# Altium schematic sheet config.SchDoc(config)
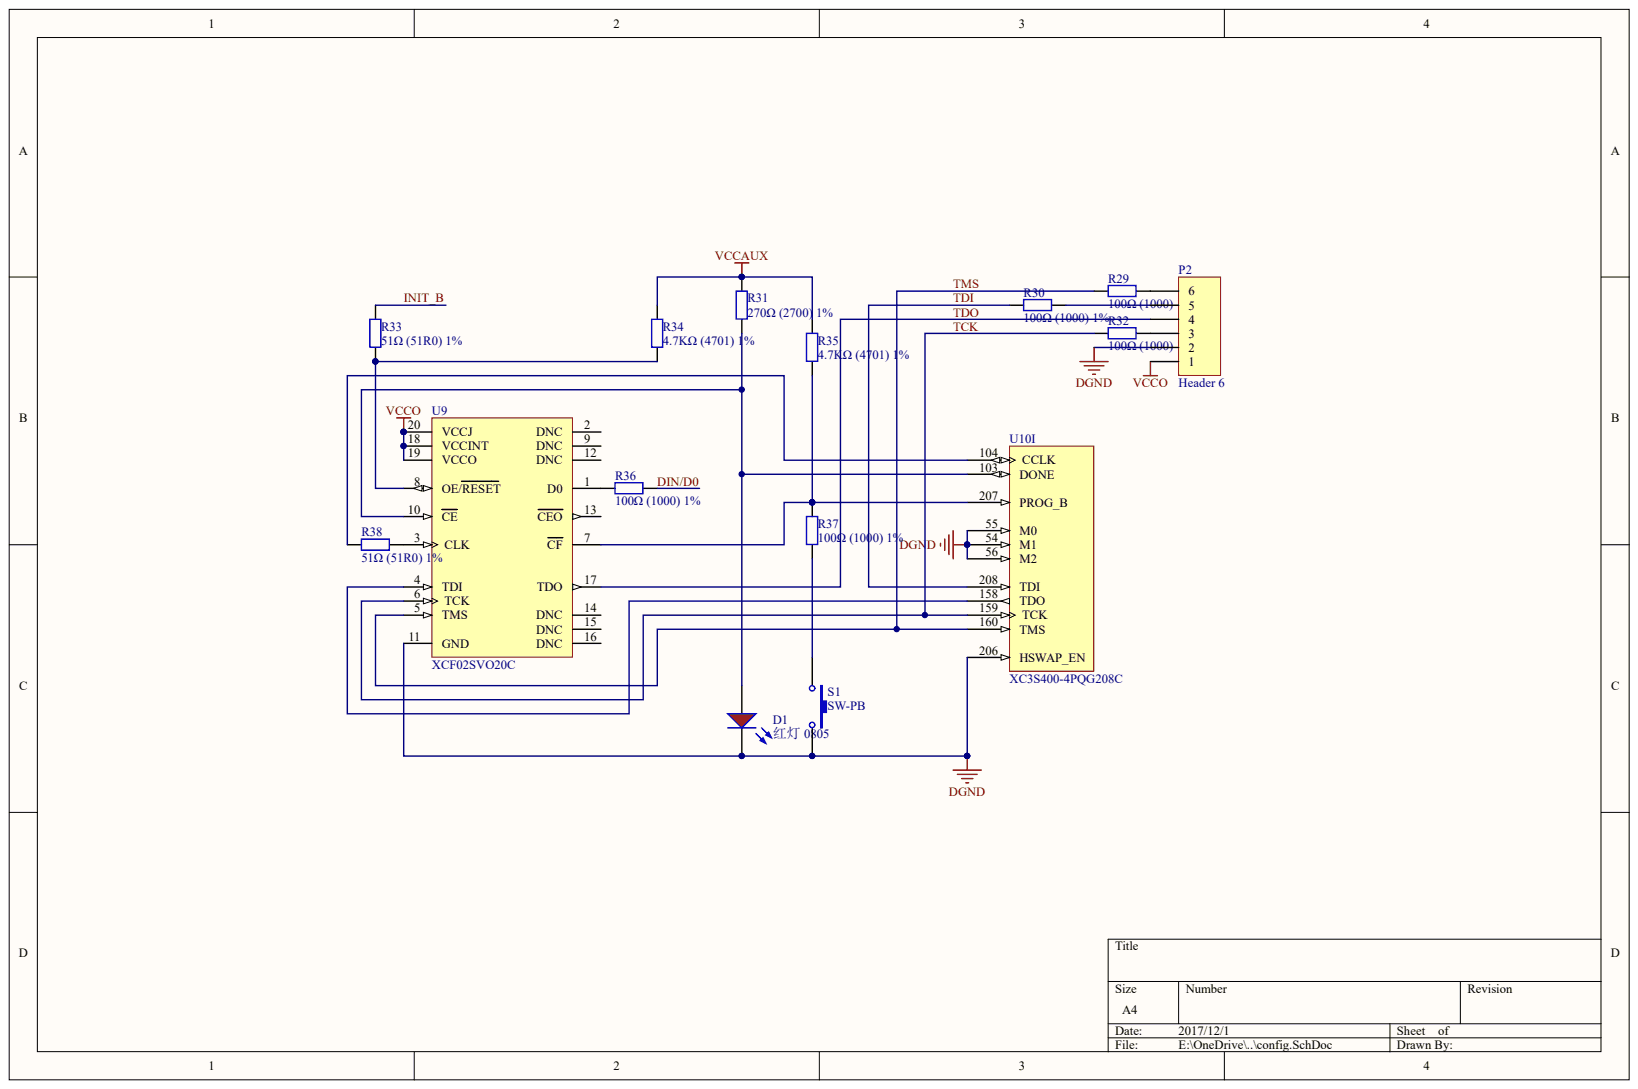
[diagram p0: config.SchDoc]

## Parts
D1  红灯 0805
P2  Header 6
R29  100Ω (1000) ±1%
R30  100Ω (1000) ±1%
R31  270Ω (2700) ±1%
R32  100Ω (1000) ±1%
R33  51Ω (51R0) ±1%  (value not drawn)
R34  4.7KΩ (4701) ±1%
R35  4.7KΩ (4701) ±1%
R36  100Ω (1000) ±1%
R37  100Ω (1000) ±1%
R38  51Ω (51R0) ±1%
S1  SW-PB
U9  XCF02SVO20C
U10  XC3S400-4PQG208C  (part I)

## Nets
DGND: D1.K P2.2(¡À1%) S1.2 U9.11(GND) U10.54(M1) U10.55(M0) U10.56(M2) U10.206(HSWAP_EN)
VCCAUX: R31.2 R34.2 R35.2
VCCO: P2.1 U9.18(VCCINT) U9.19(VCCO) U9.20(VCCJ)
DIN/D0: R36.2
INIT_B: R33.2
TCK: R32.1 U9.6(TCK) U10.159(TCK)
TDI: R30.1 U10.208(TDI)
TDO: P2.4 U9.17(TDO)
TMS: R29.1 U9.5(TMS) U10.160(TMS)
NetD1_A: D1.A R31.1 U9.10(CE) U10.103(DONE)
NetP2_3: P2.3 R32.2
NetP2_5: P2.5(¡À1%) R30.2
NetP2_6: P2.6 R29.2
NetR33_1: R33.1 R34.1 U9.8(OE/RESET)
NetR35_1: R35.1 R37.2 U9.7(CF) U10.207(PROG_B)
NetR36_1: R36.1 U9.1(D0)
NetR37_1: R37.1 S1.1
NetR38_1: R38.1 U10.104(CCLK)
NetR38_2: R38.2 U9.3(CLK)
NetU9_4: U9.4(TDI) U10.158(TDO)

Not connected: U9 2(DNC) 9(DNC) 12(DNC) 13(CEO) 14(DNC) 15(DNC) 16(DNC)

## Library data of the parts
U10 = (no symbol name): Ordering Information: Additional code may have to be appended for different mask revision devices.; FirstPublished: 16-Dec-2005; LatestRevisionDate: 05-Mar-2009; LatestRevisionNote: Updated to datasheet version 2.4 Jun-2008.; DatasheetVersion: ver2.4, Jun-2008; PackageVersion: ver1.2, Jun-2004; PackageReference: PQG208; PackageDescription: 208-Pin Plastic Quad Flat Pack (PQG208) - Pb-Free; Supplier 1: Digi-Key; Supplier Part Number 1: 122-1519-ND; Code_JEDEC: MS-029-FA-1; ComponentLink1Description: Spartan-3 Datasheet; ComponentLink1URL: http://www.xilinx.com/support/documentation/data_sheets/ds099.pdf; ComponentLink2Description: PQG208 Package Drawing; ComponentLink2URL: http://www.xilinx.com/support/documentation/package_specs/pq208.pdf; ComponentLink3Description: Spartan-3 Documents; ComponentLink3URL: http://www.xilinx.com/support/documentation/spartan-3.htm; ComponentLink4Description: Xilinx.com Home; ComponentLink4URL: http://www.xilinx.com; Publisher: Altium Limited
D1 P2 R29-R38 S1 U9 = (no symbol name)
  D1: ComponentLink1Description: 供应商链接; ComponentLink1URL: http://www.szlcsc.com/product/details_2668.html; Package: 0805; Supplier: LC; SuppliersPartNumber: C2295
  P2: LatestRevisionDate: 17-Jul-2002; LatestRevisionNote: Re-released for DXP Platform.
  R29 R30 R32 R36 R37: ComponentLink1Description: 供应商链接; ComponentLink1URL: http://www.szlcsc.com/product/details_18096.html; Package: 0805; Supplier: LC; SuppliersPartNumber: C17408
  R31: ComponentLink1Description: 供应商链接; ComponentLink1URL: http://www.szlcsc.com/product/details_18278.html; Package: 0805; Supplier: LC; SuppliersPartNumber: C17590
  R33 R38: ComponentLink1Description: 供应商链接; ComponentLink1URL: http://www.szlcsc.com/product/details_18426.html; Package: 0805; Supplier: LC; SuppliersPartNumber: C17738
  R34 R35: ComponentLink1Description: 供应商链接; ComponentLink1URL: http://www.szlcsc.com/product/details_18361.html; Package: 0805; Supplier: LC; SuppliersPartNumber: C17673
  S1: Published: 24-Mar-1999; LatestRevisionDate: 17-Jul-2002; LatestRevisionNote: Re-released for DXP Platform.; PackageReference: SPST-2; PackageDescription: Switch; 2 Leads
  U9: FirstPublished: 17-Sep-2003; LatestRevisionDate: 16-Nov-2009; LatestRevisionNote: Updated to datasheet version 2.17, Oct-2009.; DatasheetVersion: ver2.17, Oct-2009; PackageVersion: ver1.3, Dec-2008; PackageReference: VO20; PackageDescription: 20-Pin Thin Shrink Small Outline Package (VO20); Supplier 1: Digi-Key; Supplier Part Number 1: XCF02SVO20C-ND; Code_JEDEC: MO-153-AC; ComponentLink1Description: Platform Flash Datasheet; ComponentLink1URL: http://www.xilinx.com/support/documentation/data_sheets/ds123.pdf; ComponentLink2Description: VO20 Package Drawing; ComponentLink2URL: http://www.xilinx.com/support/documentation/package_specs/vo20.pdf; ComponentLink3Description: Configuration PROM Documents; ComponentLink3URL: http://www.xilinx.com/support/documentation/configuration_proms.htm; ComponentLink4Description: Xilinx.com Home; ComponentLink4URL: http://www.xilinx.com
  P2 S1 U9: Publisher: Altium Limited
